# Altium schematic sheet 5-CAN_TRANSCEIVER.SchDoc
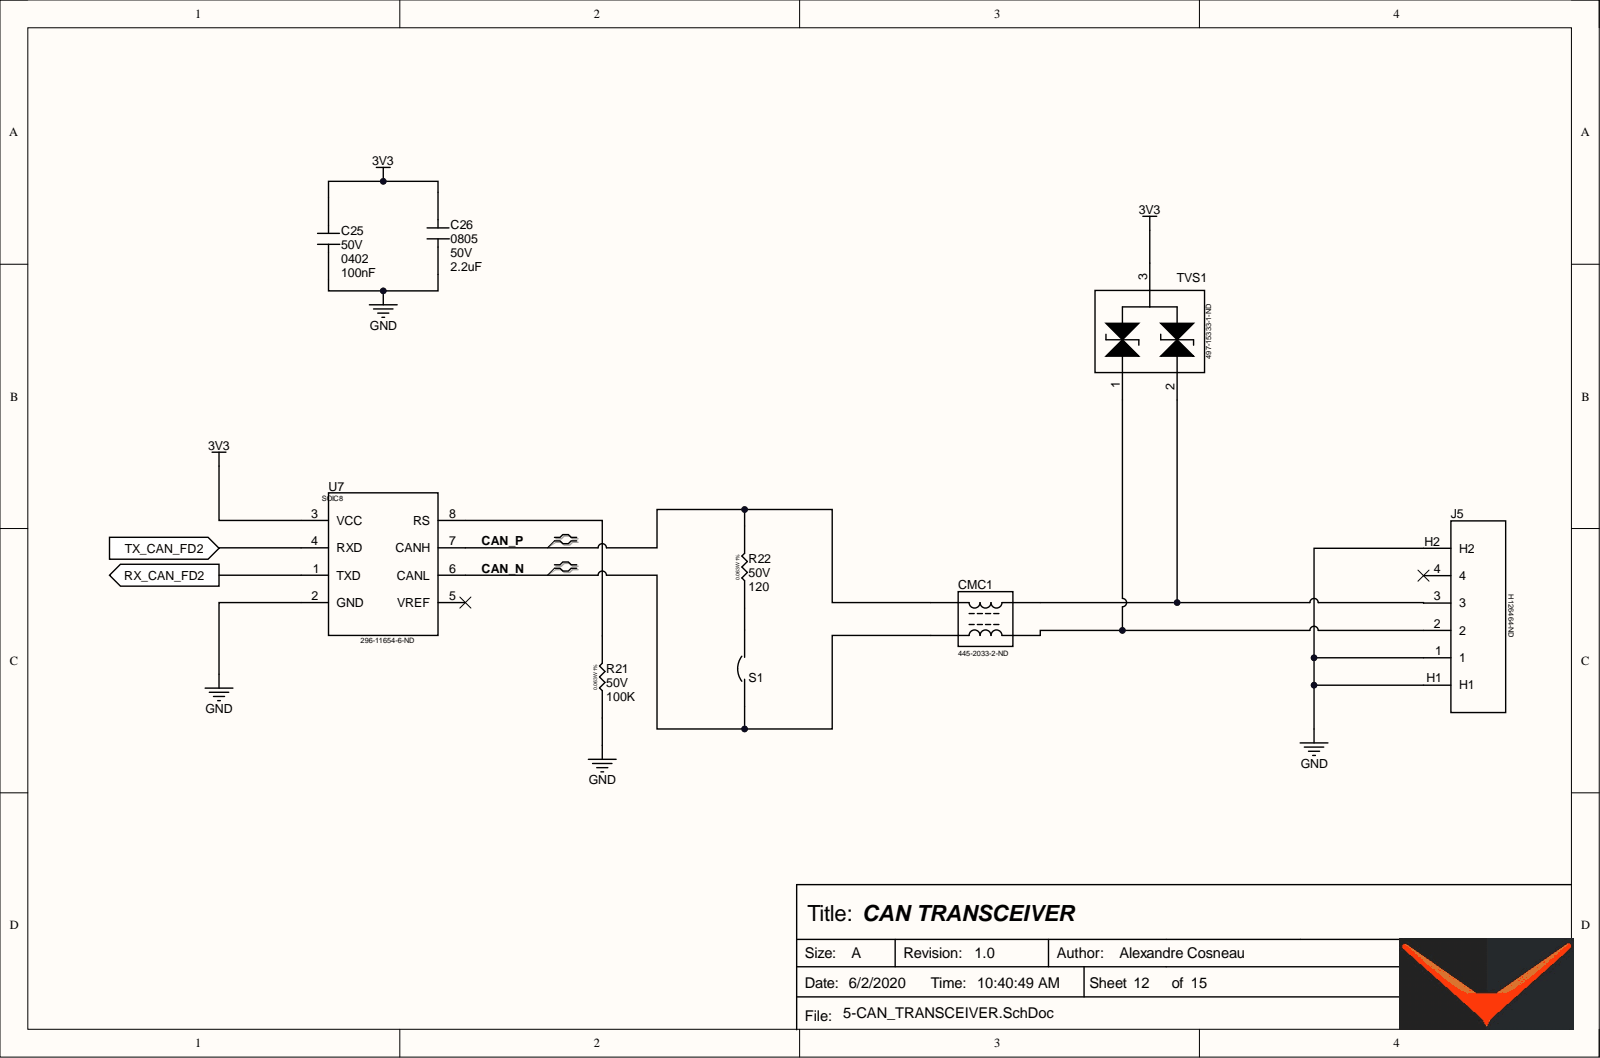
[diagram p0: 5-CAN_TRANSCEIVER.SchDoc]

## Parts
C25  100nF  Package: 0402  VDC: 50V  [C 0402(1005)x0.5]
C26  2.2uF  Package: 0805  VDC: 50V  [C 0805(2012)x0.65]
CMC1  Supplier Part Number 1: 445-2033-2-ND  [CMC_1210]  (hidden comment: TCM0403S)
J5  Supplier Part Number 1: H126464-ND  [DF13C-4P-1.25V]  (hidden comment: DF13C-4P-1.25V)
R21  100K  VDC: 50V  [R 0402(1005)-1%]
R22  120  VDC: 50V  [R 0402(1005)-1%]
S1  SHORT_PARALLEL  [SHORT_PARALLEL]  (value not drawn)
TVS1  Supplier Part Number 1: 497-15333-1-ND  [SOT23_3_0.95_1.6]  (hidden comment: SOT23)
U7  SOIC8  Supplier Part Number 1: 296-11654-6-ND  [SOIC-8_1.27_4]

## Ports
RX_CAN_FD2, TX_CAN_FD2

## Nets
3V3: C25.1 C26.1 TVS1.3 U7.3(VCC)
GND: C25.2 C26.2 J5.1 J5.H1 J5.H2 R21.1 U7.2(GND)
CAN_N: CMC1.1 S1.1 U7.6(CANL)
CAN_P: CMC1.4 R22.2 U7.7(CANH)
RX_CAN_FD2: U7.1(TXD) ; port RX_CAN_FD2
TX_CAN_FD2: U7.4(RXD) ; port TX_CAN_FD2
NetCMC1_2: CMC1.2 J5.2 TVS1.1
NetCMC1_3: CMC1.3 J5.3 TVS1.2
NetR21_2: R21.2 U7.8(RS)
NetR22_1: R22.1 S1.2

Not connected: J5 4; U7 5(VREF)

## Library data of the parts
C25 = CAP 0402 100nF 50V X7R: SMD-0402 100nF 50V X7R 10%; Manufacturer: Samsung; Part Number: CL05B104KB5NNNC; Package-mm: 1005; TYPE: Capacitors; Component Kind: Standard; ComponentLink1Description: Datasheet link; ComponentLink1URL: https://store.comet.bg/download-file.php?id=11; Library Name: LIB_PASSIVE.IntLib; Mount Type: SMT; Price: 0.039; Published: 07.04.2019; RoHS: Y; Signal Integrity: Capacitor; Simulation: Capacitor; Supplier 1: Comet; Supplier Part Number 1: C0402 100nF 50V X7R; Technology: Ceramic  MLCC; TempCo: X7R; Temperature Range: -55°C ~ +125°C; Tolerance %: ±10%; Value: 100nF; Publisher: Hefest
C26 = CAP 0805 2.2nF 50V X7R: SMD-0805 50V X7R 10%; Manufacturer: Samsung; Part Number: CL21B222KBNC; Package-mm: 2012; TYPE: Capacitors; Component Kind: Standard; ComponentLink1Description: Datasheet link; ComponentLink1URL: https://store.comet.bg/download-file.php?id=11; Library Name: LIB_PASSIVE.IntLib; Mount Type: SMT; Price: 0.026; Published: 07.04.2019; RoHS: Y; Signal Integrity: Capacitor; Simulation: Capacitor; Supplier 1: Comet; Supplier Part Number 1: C0805 2.2nF 50V X7R SAMSUNG; Technology: Ceramic  MLCC; TempCo: X7R; Temperature Range: -55°C ~ +125°C; Tolerance %: ±10%; Value: 2.2uF; Publisher: Hefest
CMC1 = TCM0403S: CMC 200mA 1K @100MHz 1210; [Author]: Alexandre Cosneau; Component Kind: Standard; Library Name: LIB_PASSIVE.IntLib; Supplier 1: Digi-Key
J5 = DF13C-4P-1.25V: HEADER MALE  4P 1.25 PITCH UP RIGHT; Component Kind: Standard; Library Name: LIB_CONNECTOR.IntLib; Supplier 1: Digi-Key
R21 R22 = RES 0402-1%: SMD 0402 0.063W 1% 50V; Manufacturer: YAGEO; Package-mm: 1005; Power: 0.0625W; TempCo: ±100ppm/°C; Value Code: 1001; Component Kind: Standard; ComponentLink1Description: Datasheet link; ComponentLink1URL: https://store.comet.bg/download-file.php?id=1224; Library Name: LIB_PASSIVE.IntLib; Mount Type: SMT; Package: 0402; Price: 0.015; Published: 07.04.2019; RoHS: Y; Signal Integrity: Resistor; Simulation: Resistor; Supplier 1: Comet; Supplier Part Number 1: R0402 1.0K 1% YAG/ASJ; Technology: Thick film; Temperature Range: -55°C ~ +155°C; Tolerance %: ±1%; TYPE: Resistor; Publisher: Hefest
  R21: Value: 100K
  R22: Value: 120
S1 = SHORT_PARALLEL: SHORTABLE PARALLEL PCB LINK; Component Kind: Net Tie; Library Name: LIB_ELECTRO-MECHA.IntLib
TVS1 = ESDCAN01: TVS CAN PROTECT; Component Kind: Standard; Library Name: LIB_PASSIVE.IntLib; PACKAGE: SOT23; Supplier 1: Digi-Key; TYPE: TVS
U7 = SN65HVD230: CAN TRANSCIEVER; Component Kind: Standard; Library Name: LIB_IC.IntLib; Supplier 1: Digi-Key
